# Altium schematic sheet Input_1.SchDoc(Input_1)
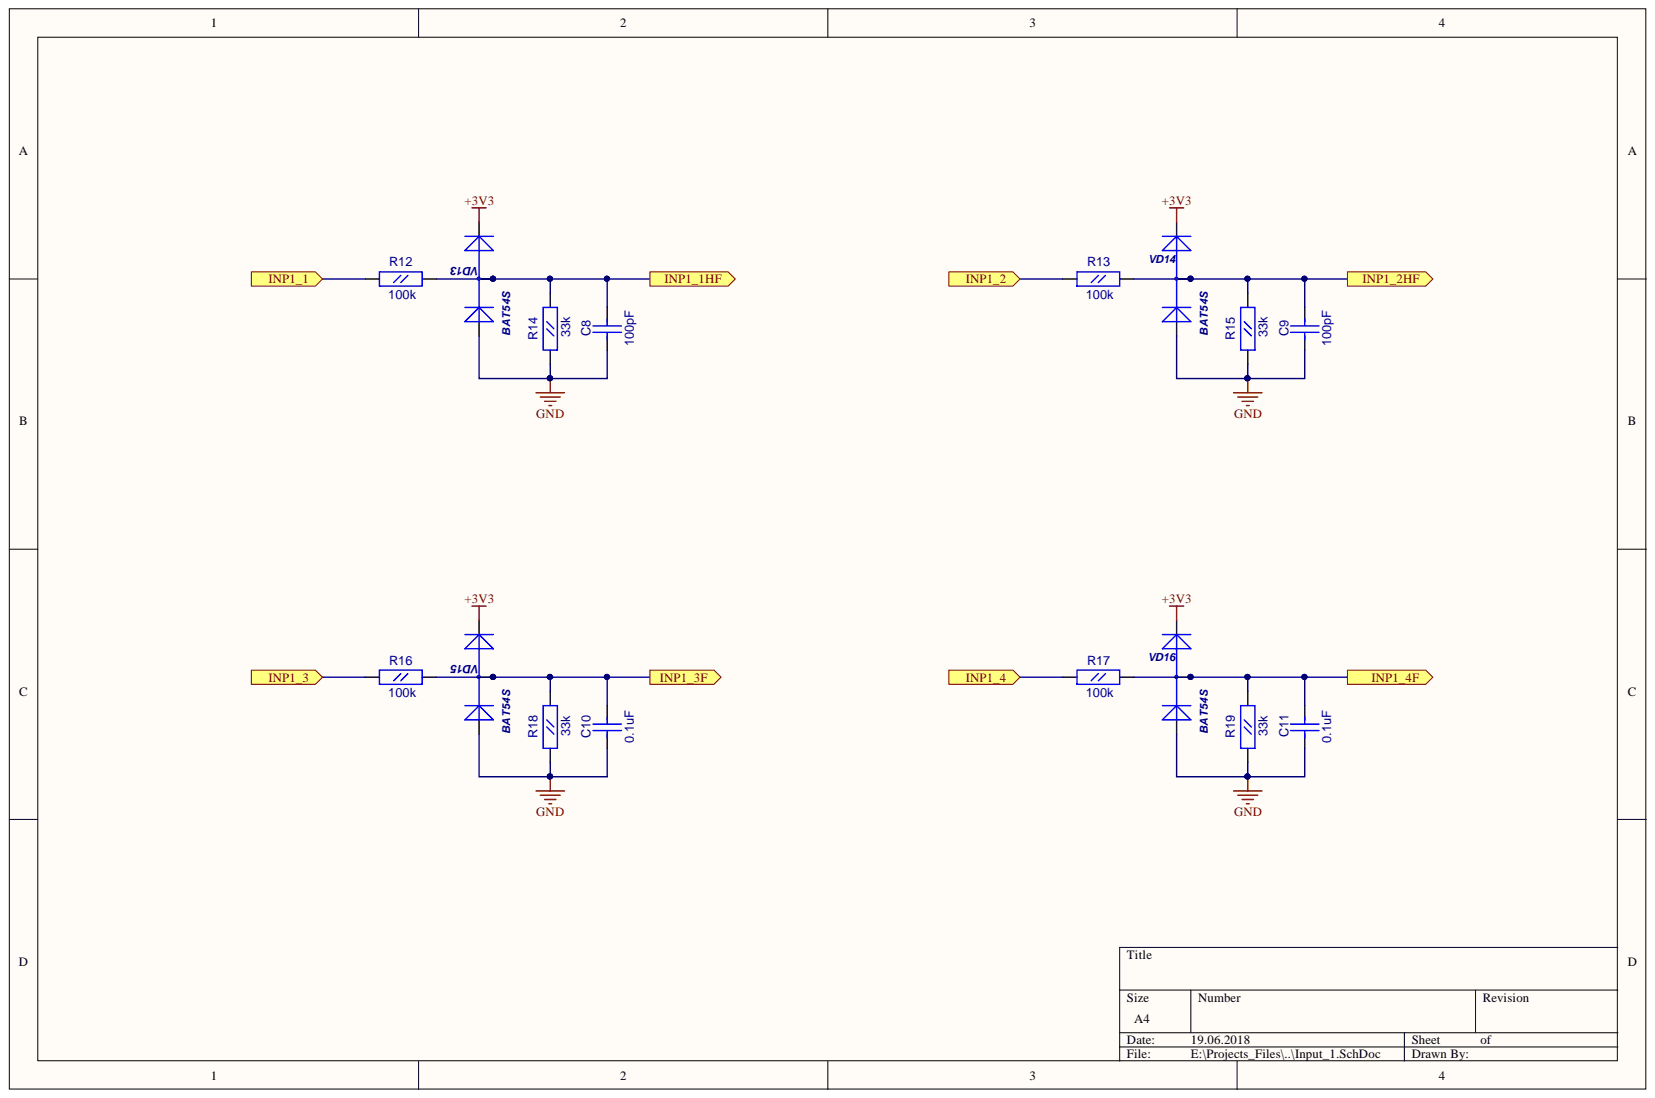
[diagram p0: Input_1.SchDoc]

## Parts
C8  100pF  [CC0603]
C9  100pF  [CC0603]
C10  0.1uF  [CC0603]
C11  0.1uF  [CC0603]
R12  100k  [RC0603]
R13  100k  [RC0603]
R14  33k  [RC0603]
R15  33k  [RC0603]
R16  100k  [RC0603]
R17  100k  [RC0603]
R18  33k  [RC0603]
R19  33k  [RC0603]
VD13  BAT54S  [SOT-23(GSD)]
VD14  BAT54S  [SOT-23(GSD)]
VD15  BAT54S  [SOT-23(GSD)]
VD16  BAT54S  [SOT-23(GSD)]

## Ports
INP1_1, INP1_1HF, INP1_2, INP1_2HF, INP1_3, INP1_3F, INP1_4, INP1_4F

## Nets
+3V3: VD13.2 VD14.2 VD15.2 VD16.2
GND: C8.1 C9.1 C10.1 C11.1 R14.1 R15.1 R18.1 R19.1 VD13.1 VD14.1 VD15.1 VD16.1
INP1_1: R12.1 ; port INP1_1
INP1_1HF: C8.2 R12.2 R14.2 VD13.3 ; port INP1_1HF
INP1_2: R13.1 ; port INP1_2
INP1_2HF: C9.2 R13.2 R15.2 VD14.3 ; port INP1_2HF
INP1_3: R16.1 ; port INP1_3
INP1_3F: C10.2 R16.2 R18.2 VD15.3 ; port INP1_3F
INP1_4: R17.1 ; port INP1_4
INP1_4F: C11.2 R17.2 R19.2 VD16.3 ; port INP1_4F

## Library data of the parts
C8-C11 = CC0603: Description: CC0603; Component Kind: Standard; Library Name: gprs_modem_2.SchLib
  C8 C9: Value: 100pF
  C10 C11: Value: 0.1uF
R12-R19 = RC0603: Description: RC0603; Component Kind: Standard; Library Name: gprs_modem_2.SchLib
  R12 R13 R16 R17: Value: 100k
  R14 R15 R18 R19: Value: 33k
VD13-VD16 = BAT54S: 1.0 Ampere General Purpose Rectifier; ComponentField6: Published 8-Jun-2000, Modified 11-July-2000: SIM Model Added.; Simulation: DIODE; Component Kind: Standard; Library Name: gprs_modem_2.SchLib
